# Altium schematic sheet DE-260924.SchDoc(DE-260924)
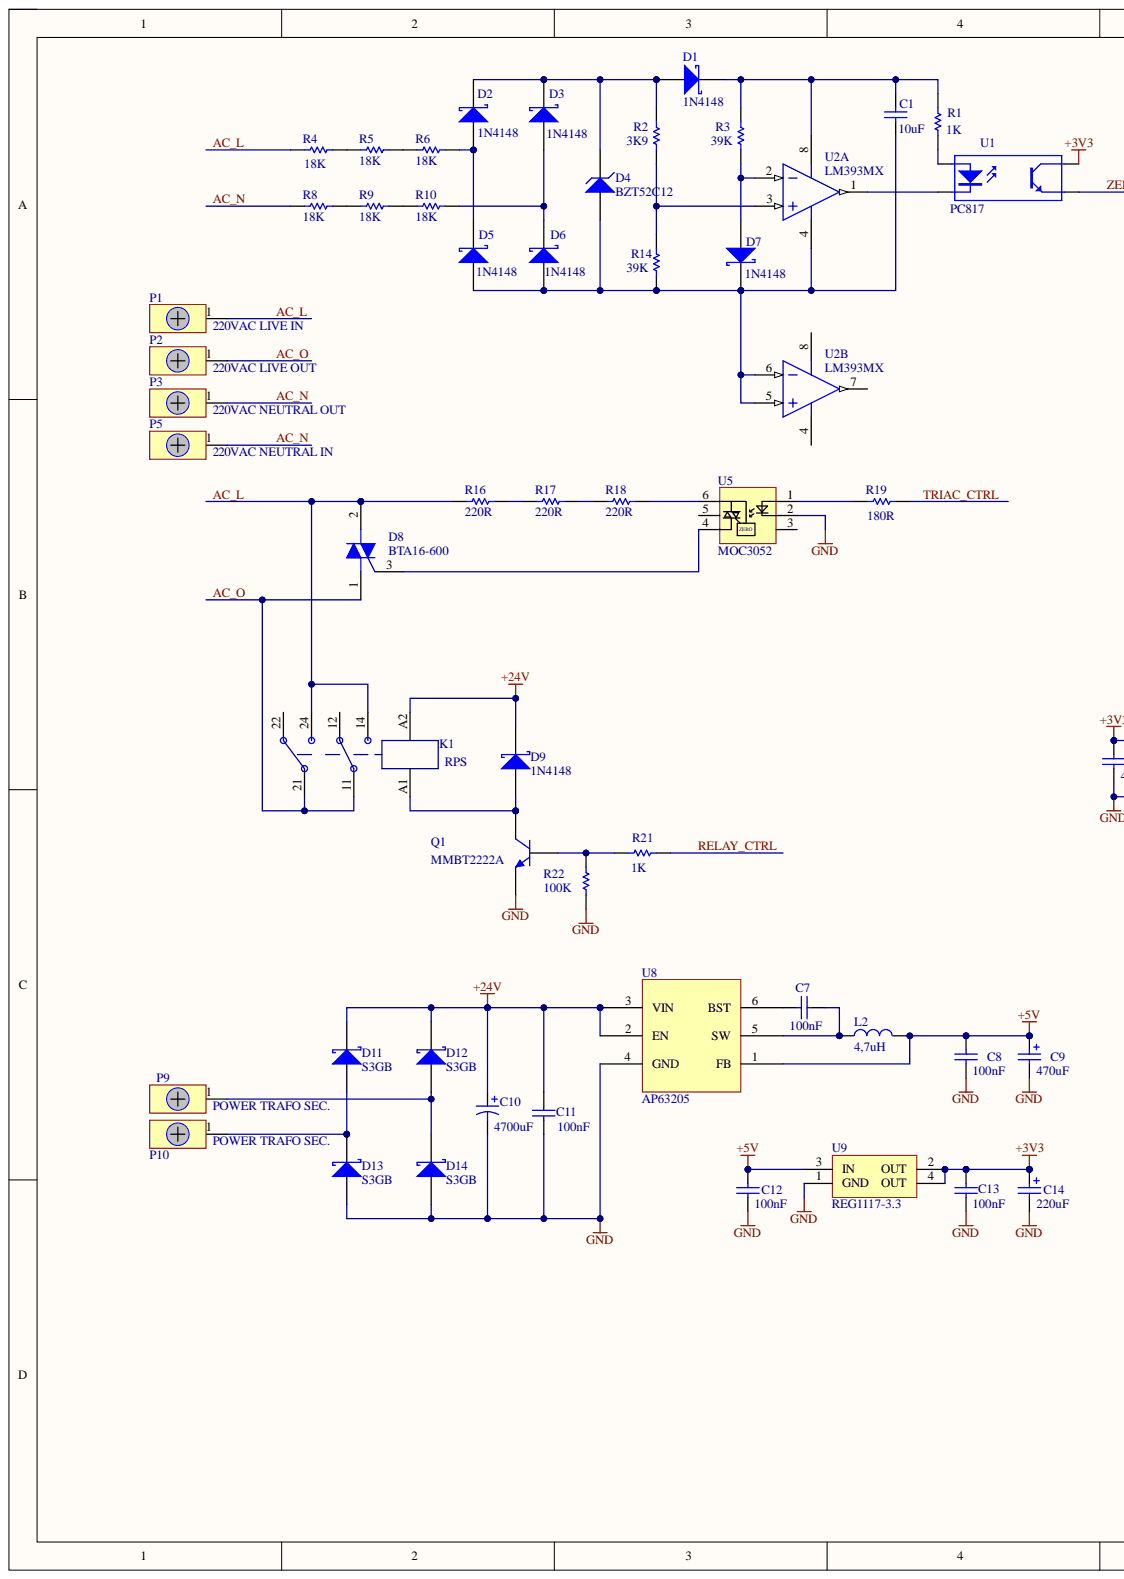
[diagram p0: DE-260924.SchDoc, left]
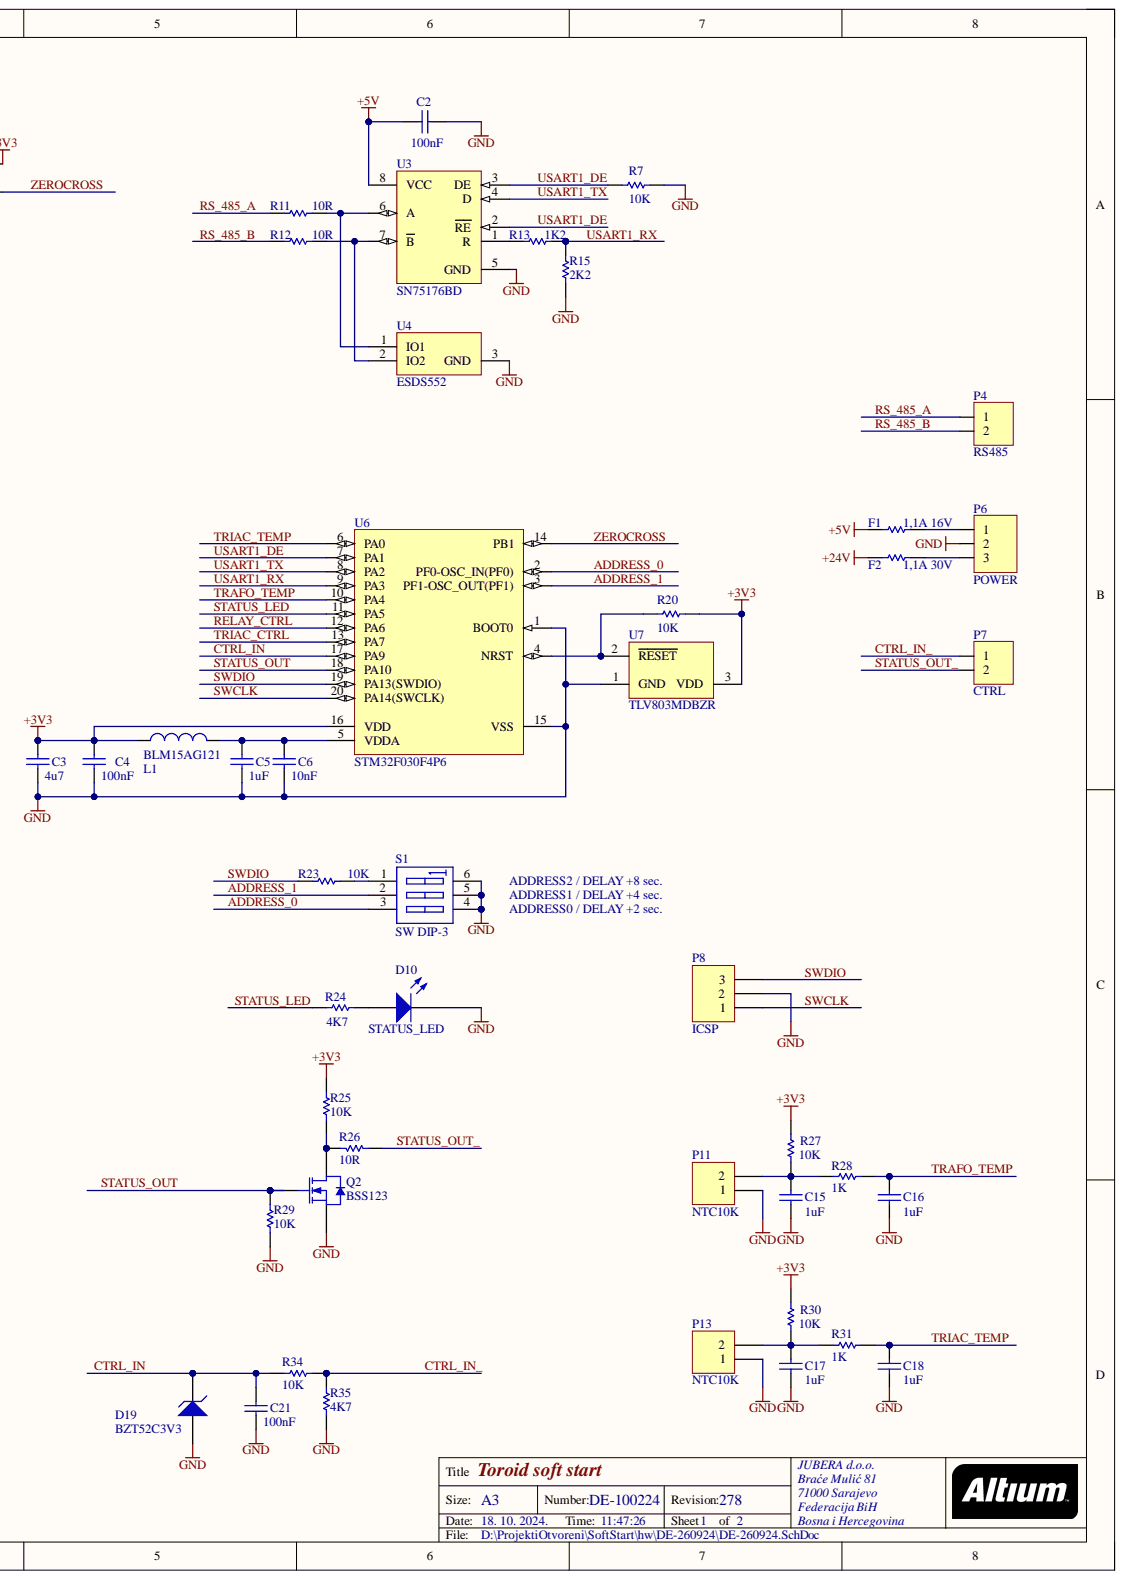
[diagram p1: DE-260924.SchDoc, right]

## Parts
C1  value 10uF  [0805]
C2  value 100nF  [0603]
C3  value 4u7  [0805]  (hidden comment: C0805)
C4  value 100nF  [0603]  (hidden comment: C0603)
C5  value 1uF  [0603]  (hidden comment: C0603)
C6  value 10nF  [0603]  (hidden comment: C0603)
C7  value 100nF  [0603]
C8  value 100nF  [0603]  (hidden comment: C0603)
C9  470uF  [SMD,D6.3xL7.7mm Aluminum Electrolytic Capacitors]
C10  4700uF  [CAPPR5-13X25]
C11  value 100nF  [0603]
C12  value 100nF  [0603]
C13  value 100nF  [0603]
C14  220uF  [SMD,D6.3xL7.7mm Aluminum Electrolytic Capacitors]
C15  1uF  [0603]
C16  value 1uF  [0603]
C17  value 1uF  [0603]
C18  value 1uF  [0603]
C21  value 100nF  [0603]
D1  1N4148  [SOD323]
D2  1N4148  [SOD323]
D3  1N4148  [SOD323]
D4  BZT52C12  [SOD-123]
D5  1N4148  [SOD323]
D6  1N4148  [SOD323]
D7  1N4148  [SOD323]
D8  BTA16-600  [ONSC-TO-220-3-221A-07]
D9  1N4148  [SOD323]
D10  STATUS_LED  [1.6X0.8X0.8]
D11  S3GB  [SMB]
D12  S3GB  [SMB]
D13  S3GB  [SMB]
D14  S3GB  [SMB]
D19  BZT52C3V3  [SOD-123]
F1  1,1A 16V  [12-1812]
F2  1,1A 30V  [12-1812]
K1  RPS  [RPS 2CO 8A 24V]
L1  BLM15AG121  [0603]
L2  4,7uH  [XRNR4030-4.7uH/M]
P1  220VAC LIVE IN  [WIRE PAD]
P2  220VAC LIVE OUT  [WIRE PAD]
P3  220VAC NEUTRAL OUT  [WIRE PAD]
P4  RS485  [TERMINAL BLOK 2]
P5  220VAC NEUTRAL IN  [WIRE PAD]
P6  POWER  [TERMINAL BLOK 3]
P7  CTRL  [TERMINAL BLOK 2]
P8  ICSP  [HDR1X3]
P9  POWER TRAFO SEC.  [WIRE PAD]
P10  POWER TRAFO SEC.  [WIRE PAD]
P11  NTC10K  [HDR1X2]
P13  NTC10K  [HDR1X2]
Q1  MMBT2222A  [SOT23_N]
Q2  BSS123  [SOT23_N]
R1  1K  [ERA-0603]
R2  3K9  [ERA-0603]
R3  39K  [ERA-0603]
R4  value 18K  [ERA-0805]  (hidden comment: 0805)
R5  18K  [ERA-0805]
R6  18K  [ERA-0805]
R7  10K  [ERA-0603]
R8  18K  [ERA-0805]
R9  18K  [ERA-0805]
R10  18K  [ERA-0805]
R11  10R  [ERA-0805]
R12  10R  [ERA-0805]
R13  1K2  [ERA-0603]
R14  39K  [ERA-0603]
R15  2K2  [ERA-0603]
R16  220R  [ERA-0805]
R17  220R  [ERA-0805]
R18  220R  [ERA-0805]
R19  180R  [ERA-0603]
R20  value 10K  [ERA-0603]  (hidden comment: 180R)
R21  1K  [ERA-0603]
R22  100K  [ERA-0603]
R23  value 10K  [ERA-0603]  (hidden comment: 180R)
R24  value 4K7  [ERA-0603]  (hidden comment: 180R)
R25  value 10K  [ERA-0603]  (hidden comment: 180R)
R26  10R  [ERA-0603]
R27  10K  [ERA-0603]
R28  1K  [ERA-0603]
R29  value 10K  [ERA-0603]  (hidden comment: 180R)
R30  10K  [ERA-0603]
R31  1K  [ERA-0603]
R34  value 10K  [ERA-0603]  (hidden comment: 180R)
R35  value 4K7  [ERA-0603]  (hidden comment: 180R)
S1  SW DIP-3  [DIP-6]
U1  PC817  [DIP-4]
U2  LM393MX  [M08A_N]  (parts A,B)
U3  SN75176BD  [D008_N]
U4  ESDS552  [DCK3_N]
U5  MOC3052  [DIP6]
U6  STM32F030F4P6  [STM-TSSOP20_N]
U7  TLV803MDBZR  [DBZ3_N]
U8  AP63205  [TSOT-26]
U9  REG1117-3.3  [ZZ311]

## Nets
+3V3: C3.2 C4.2 C13.2 C14.1 L1.1 R20.1 R25.1 R27.2 R30.2 U1.4 U6.16(VDD) U7.3 U9.2(OUT) U9.4(OUT)
+5V: C2.2 C8.2 C9.1 C12.2 F1.1 L2.1 U3.8(VCC) U8.1(FB) U9.3(IN)
+24V: C10.1 C11.2 D9.1 D11.2 D12.2 F2.1 K1.A2 U8.2(EN) U8.3(VIN)
GND: C2.1 C3.1 C4.1 C5.1 C6.1 C8.1 C9.2 C10.2 C11.1 C12.1 C13.1 C14.2 C15.2 C16.2 C17.2 C18.2 C21.1 D10.2 D13.1 D14.1 D19.1 P6.2 P8.2 P11.1 P13.1 Q1.2 Q2.2 R7.2 R15.1 R22.1 R29.2 R35.2 S1.4 S1.5 S1.6 U3.5(GND) U4.3 U5.2 U6.1(BOOT0) U6.15(VSS) U7.1 U8.4(GND) U9.1(GND)
AC_L: D8.2 K1.14 K1.24 P1.1 R4.1 R16.1
AC_N: P3.1 P5.1 R8.1
AC_O: D8.1 K1.11 K1.21 P2.1
ADDRESS_0: S1.3 U6.2(PF0-OSC_IN(PF0))
ADDRESS_1: S1.2 U6.3(PF1-OSC_OUT(PF1))
CTRL_IN: C21.2 D19.2 R34.1 U6.17(PA9)
CTRL_IN_: P7.1 R34.2 R35.1
RELAY_CTRL: R21.1 U6.12(PA6)
RS_485_A: P4.1 R11.1
RS_485_B: P4.2 R12.1
STATUS_LED: R24.2 U6.11(PA5)
STATUS_OUT: Q2.1 R29.1 U6.18(PA10)
STATUS_OUT_: P7.2 R26.2
SWCLK: P8.1 U6.20(PA14(SWCLK))
SWDIO: P8.3 R23.2 U6.19(PA13(SWDIO))
TRAFO_TEMP: C16.1 R28.1 U6.10(PA4)
TRIAC_CTRL: R19.1 U6.13(PA7)
TRIAC_TEMP: C18.1 R31.1 U6.6(PA0)
USART1_DE: R7.1 U3.2(RE) U3.3(DE) U6.7(PA1)
USART1_RX: R13.2 R15.2 U6.9(PA3)
USART1_TX: U3.4(D) U6.8(PA2)
ZEROCROSS: U1.3 U6.14(PB1)
NetC1_1: C1.1 D4.1 D5.2 D6.2 D7.1 R14.1 U2.4 U2.5 U2.6
NetC1_2: C1.2 D1.1 R1.2 R3.2 U2.8
NetC5_2: C5.2 C6.2 L1.2 U6.5(VDDA)
NetC7_1: C7.1 L2.2 U8.5(SW)
NetC7_2: C7.2 U8.6(BST)
NetC15_1: C15.1 P11.2 R27.1 R28.2
NetC17_1: C17.1 P13.2 R30.1 R31.2
NetD1_2: D1.2 D2.1 D3.1 D4.2 R2.2
NetD2_2: D2.2 D5.1 R6.2
NetD3_2: D3.2 D6.1 R10.2
NetD7_2: D7.2 R3.1 U2.2
NetD8_3: D8.3 U5.4
NetD9_2: D9.2 K1.A1 Q1.3
NetD10_1: D10.1 R24.1
NetD11_1: D11.1 D13.2 P10.1
NetD12_1: D12.1 D14.2 P9.1
NetF1_2: F1.2 P6.1
NetF2_2: F2.2 P6.3
NetQ1_1: Q1.1 R21.2 R22.2
NetQ2_3: Q2.3 R25.2 R26.1
NetR1_1: R1.1 U1.1
NetR2_1: R2.1 R14.2 U2.3
NetR4_2: R4.2 R5.1
NetR5_2: R5.2 R6.1
NetR8_2: R8.2 R9.1
NetR9_2: R9.2 R10.1
NetR11_2: R11.2 U3.6(A) U4.1
NetR12_2: R12.2 U3.7(B) U4.2
NetR13_1: R13.1 U3.1(R)
NetR16_2: R16.2 R17.1
NetR17_2: R17.2 R18.1
NetR18_2: R18.2 U5.6
NetR19_2: R19.2 U5.1
NetR20_2: R20.2 U6.4(NRST) U7.2
NetR23_1: R23.1 S1.1
NetU1_2: U1.2 U2.1

Not connected: K1 12 22; U2 7; U5 3 5

## Library data of the parts
C1 C3 = C0805: Ceramic Chip Capacitor - Standard; Note: Footprint corresponds to dimensions for bulk cassette packaging. This case size has tightened tolerances.; Code_IPC: 2012[0805]; Code_JEITA: 0805; Component Kind: Standard; ComponentLink1Description: Manufacturer Link; ComponentLink1URL: http://www.kemet.com/; ComponentLink2Description: Datasheet; ComponentLink2URL: http://www.kemet.com/kemet/web/homepage/kechome.nsf/vapubfilesname/F3102TA.pdf/$file/F3102TA.pdf; DatasheetDocument: Mar-2001; LatestRevisionDate: 19-Mar-2004; LatestRevisionNote: Silkscreen size reduced.; Library Name: DE-260924.SchLib; PackageDocument: Mar-2001; PackageReference: 0805; Published: 17-Jul-2002; Signal Integrity: C0805; Simulation: CAP; Publisher: Altium Limited
C2 C4-C6 C11 C13 C15-C18 C21 = C0603: Ceramic Chip Capacitor - Standard; Note: Footprint corresponds to dimensions for bulk cassette packaging. This case size has tightened tolerances.; Code_IPC: 1608 [0603]; Code_JEITA: 0603; Component Kind: Standard; ComponentLink1Description: Manufacturer Link; ComponentLink1URL: http://www.kemet.com/; ComponentLink2Description: Datasheet; ComponentLink2URL: http://www.kemet.com/kemet/web/homepage/kechome.nsf/vapubfilesname/F3102TA.pdf/$file/F3102TA.pdf; DatasheetDocument: Mar-2001; LatestRevisionDate: 19-Mar-2004; LatestRevisionNote: Silkscreen size reduced.; Library Name: DE-260924.SchLib; PackageDocument: Mar-2001; PackageReference: 0603; Published: 17-Jul-2002; Signal Integrity: C0603; Simulation: CAP; Publisher: Altium Limited
  C15: Value: 1uF
C7 C8 C12 = C0603: Ceramic Chip Capacitor - 25V; Note: Footprint corresponds to dimensions for bulk cassette packaging. This case size has tightened tolerances.; Code_IPC: 1608 [0603]; Code_JEITA: 0603; Component Kind: Standard; ComponentLink1Description: Manufacturer Link; ComponentLink1URL: http://www.kemet.com/; ComponentLink2Description: Datasheet; ComponentLink2URL: http://www.kemet.com/kemet/web/homepage/kechome.nsf/vapubfilesname/F3102TA.pdf/$file/F3102TA.pdf; DatasheetDocument: Mar-2001; LatestRevisionDate: 19-Mar-2004; LatestRevisionNote: Silkscreen size reduced.; Library Name: DE-260924.SchLib; PackageDocument: Mar-2001; PackageReference: 0603; Published: 17-Jul-2002; Signal Integrity: C0603; Simulation: CAP; Publisher: Altium Limited
C9 = ROQANG RVT1C471M0607: 470uF 16V 185mA@120Hz ±20% SMD,D6.3xL7.7mm Aluminum Electrolytic Capacitors - SMD ROHS; DrillSize: Nominal, Rounded To The Nearest 0.1mm; Note: Refer to Manufacturer's Data Sheets for other lead formations; Value: 10uF; Component Kind: Standard; ComponentLink1Description: Manufacturer Link; ComponentLink1URL: http://www.vishay.com/; ComponentLink2Description: Datasheet; ComponentLink2URL: http://www.vishay.com/docs/25014/eka.pdf; DatasheetDocument: 20-Feb-2001; Library Name: DE-260924.SchLib; PackageReference: CAPPR2-5X11; Published: 19-Mar-2004; Publisher: Altium Limited
C10 = EKA00GG447C00: Aluminum Electrolytic Capacitor, Radial Style with Long Leads: 4700uF, 10V; DrillSize: Nominal, Rounded To The Nearest 0.1mm; Note: Refer to Manufacturer's Data Sheets for other lead formations; Component Kind: Standard; ComponentLink1Description: Manufacturer Link; ComponentLink1URL: http://www.vishay.com/; ComponentLink2Description: Datasheet; ComponentLink2URL: http://www.vishay.com/docs/25014/eka.pdf; DatasheetDocument: 20-Feb-2001; PackageReference: CAPPR5-13X25; Published: 19-Mar-2004; Signal Integrity: EKA00GG447C00; Simulation: CAP; Value: 4700uF; Library Name: Vishay Roederstein Electrolytic Radial-Lead Capacitor.IntLib; Publisher: Altium Limited
C14 = ROQANG VT1C221M0607: 220uF 16V 110mA@120Hz ±20% SMD,D6.3xL7.7mm Aluminum Electrolytic Capacitors - SMD ROHS; DrillSize: Nominal, Rounded To The Nearest 0.1mm; Note: Refer to Manufacturer's Data Sheets for other lead formations; Value: 10uF; Component Kind: Standard; ComponentLink1Description: Manufacturer Link; ComponentLink1URL: http://www.vishay.com/; ComponentLink2Description: Datasheet; ComponentLink2URL: http://www.vishay.com/docs/25014/eka.pdf; DatasheetDocument: 20-Feb-2001; Library Name: DE-260924.SchLib; PackageReference: CAPPR2-5X11; Published: 19-Mar-2004; Publisher: Altium Limited
D1-D3 D5-D7 D9 = 1PS76SB10: Schottky Barrier Diode; Component Kind: Standard; ComponentLink1Description: Manufacturer Link; ComponentLink1URL: http://www.semiconductors.philips.com/; ComponentLink2Description: Datasheet; ComponentLink2URL: http://www.semiconductors.philips.com/acrobat/datasheets/1PS76SB10_2.pdf; DatasheetVersion: 14-Oct-1996; LatestRevisionDate: 19-Mar-2004; LatestRevisionNote: Silkscreen size reduced.; Library Name: DE-100224.SchLib; PackageDescription: SOD-323/SC-76; 2 Leads; Body 1.7 x 1.3 mm, (LxW); PackageReference: SOD323; PackageVersion: May-1999; Published: 17-Sep-2003; Signal Integrity: 1PS76SB10; Simulation: D1PS76SBD10; Publisher: Altium Limited
D4 = BZT52C12: Surface Mount Zener Diode; Component Kind: Standard; ComponentLink1Description: Manufacturer Link; ComponentLink1URL: http://www.vishay.com/; DatasheetDocument: REV. L-2; LatestRevisionDate: 17-Jul-2002; LatestRevisionNote: Re-released for DXP Platform.; Library Name: Vishay Lite-On Discrete Diode.IntLib; PackageReference: SOD-123; Published: 8-Jun-2000; Signal Integrity: BZT52C12; Simulation: BZT52C12; Publisher: Altium Limited
D8 = BTA08-600BW3G: Triacs, Silicon Bidirectional Thyristor, 3-Pin TO-220, Pb-Free, Tube; Manufacturer: On Semiconductor; IGT Max (mA): 50; IT(RMS) Max (A): 8; ITSM Max (A): 90; Component Kind: Standard; ComponentLink1Description: Manufacturer URL; ComponentLink1URL: http://www.onsemi.com/; ComponentLink2Description: Datasheet; ComponentLink2URL: http://www.onsemi.com/pub_link/Collateral/BTA08-600BW3-D.PDF; ComponentLink3Description: Package Specification; ComponentLink3URL: http://www.onsemi.com/pub_link/Collateral/221A-07.PDF; DatasheetVersion: Rev. 1; Library Name: DE-100224.SchLib; Mounting Technology: Through Hole; PackageDescription: 3-Pin Flange Mount, Vertical Straight Lead, Body 9.97 x 4.445 mm, Pitch 2.54 mm; PackageReference: TO-220-3-221A-07; PackageVersion: Rev. O, 07/2008; Packing: Tube; PartNumber: BTA08-600BW3G; RoHS: Pb-Free; Supplier 1: Digi-Key; Supplier 2: Newark; Supplier 3: Mouser; Supplier Part Number 1: BTA08-600BW3G-ND; Supplier Part Number 2: 13P2072; Supplier Part Number 3: 863-BTA08-600BW3G
D10 = HSMB-C190: Blue Surface Mount Chip LED, Blue; LuminousIntensity: 1.6mcd (Minimum).; PCB_Layout: Complies with manufacturer's recommendation.; Component Kind: Standard; ComponentLink1Description: Manufacturer Link; ComponentLink1URL: http://www.avagotech.com/; ComponentLink2Description: Datasheet; ComponentLink2URL: http://cp.literature.agilent.com/litweb/pdf/5988-5211EN.pdf; DatasheetVersion: 26-Feb-2002; LED_Colour: Blue; Library Name: DE-160724.SchLib; PackageDescription: SM LED; 2 Flat Leads; PackageReference: 1.6X0.8X0.8; PackageVersion: Mar-2002; Published: 11-Dec-2002; Signal Integrity: LED; Simulation: Agl_HSMBC110; Publisher: Altium Limited
D11-D14 = D Schottky: Schottky Diode; Code_JEDEC: DO-214-AA; Component Kind: Standard; LatestRevisionDate: 17-Jul-2002; LatestRevisionNote: Re-released for DXP Platform.; Library Name: Miscellaneous Devices.IntLib; PackageDescription: DO-214-AA/SMB; 2 C-Bend Leads; Body 5.3 x 3.6 mm, inc. leads (LxW); PackageReference: SMB; Published: 8-Jun-2000; Signal Integrity: D Schottky; Simulation: SKYDIODE; Publisher: Altium Limited
D19 = BZT52C3V3: Surface Mount Zener Diode; Component Kind: Standard; ComponentLink1Description: Manufacturer Link; ComponentLink1URL: http://www.vishay.com/; DatasheetDocument: REV. L-2; LatestRevisionDate: 17-Jul-2002; LatestRevisionNote: Re-released for DXP Platform.; Library Name: Vishay Lite-On Discrete Diode.IntLib; PackageReference: SOD-123; Published: 8-Jun-2000; Signal Integrity: BZT52C3V3; Simulation: BZT52C3V3; Publisher: Altium Limited
F1 F2 = ERJL12: Retriggerable SMD fuse 1,1A, 16V; Component Kind: Standard; ComponentLink1Description: Manufacturer Link; ComponentLink1URL: http://www.panasonic.com/industrial/components/resistive_products.htm; ComponentLink2Description: Datasheet; ComponentLink2URL: http://www.panasonic.com/industrial/components/pdf/AOA0000CE3.pdf; LatestRevisionDate: 19-Mar-2004; LatestRevisionNote: Silkscreen size reduced.; Library Name: DE-260924.SchLib; PackageDocument: Mar-2003; PackageReference: 12-1812; Published: 17-Dec-2003; Signal Integrity: Res; Simulation: RESISTOR; Publisher: Altium Limited
K1 = PCB relay 2CO, 8A, 24VDC, RPS serie 5mm: Component Kind: Standard; Library Name: DE-260924.SchLib
L1 = Chip inductor: SMD chip inductor; Code_IEC: 1005; Code_JEITA: 0402; Component Kind: Standard; LatestRevisionDate: 29-May-2009; LatestRevisionNote: IPC-7351 Footprint Added.; Library Name: DE-260924.SchLib; PackageDescription: Chip Inductor; PackageReference: 0402-A; Published: 8-Jun-2000; Signal Integrity: Inductor; Simulation: INDUCTOR; Publisher: Altium Limited
L2 = Inductor: Component Kind: Standard; Library Name: DE-260924.SchLib
P1-P3 P5 P9 P10 = Wire Lug: Component Kind: Standard; Library Name: DE-260924.SchLib
P4 P7 = TERMINAL BLOK 2: Terminal blok konektor 3,5 mm; Component Kind: Standard; Library Name: DE-160724.SchLib
P6 = TERMINAL BLOK 3: 3 pinski terminal blok konektor; Component Kind: Standard; Library Name: DE-100224.SchLib
P8 = Header 3: Header, 3-Pin; Component Kind: Standard; LatestRevisionDate: 17-Jul-2002; LatestRevisionNote: Re-released for DXP Platform.; Library Name: Miscellaneous Connectors.IntLib; Signal Integrity: Connector; Publisher: Altium Limited
P11 P13 = Header 2: Header, 2-Pin; Component Kind: Standard; LatestRevisionDate: 17-Jul-2002; LatestRevisionNote: Re-released for DXP Platform.; Library Name: Miscellaneous Connectors.IntLib; Signal Integrity: Connector; Publisher: Altium Limited
Q1 = BC817: NPN General-purpose Transistor; Component Kind: Standard; ComponentLink1Description: Manufacturer Link; ComponentLink1URL: http://www.semiconductors.philips.com/; ComponentLink2Description: Datasheet; ComponentLink2URL: http://www.semiconductors.philips.com/acrobat/datasheets/BC817_BC817W_BC337_5.pdf; DatasheetVersion: Jun-1999; LatestRevisionDate: 12-Dec-2006; LatestRevisionNote: IPC-7351 Footprint Added.; Library Name: DE-100224.SchLib; PackageDescription: 3-Pin SOT-23 Package 0.95 mm Pitch, 2.3 mm Lead Span; PackageReference: SOT23; PackageVersion: May-1999; Published: 17-Sep-2003; Signal Integrity: BC817; Simulation: QBC817; Publisher: Altium Limited
Q2 = BSS123: N-channel Enhancement Mode Vertical D-MOSFET; Component Kind: Standard; ComponentLink1Description: Manufacturer Link; ComponentLink1URL: http://www.semiconductors.philips.com/; ComponentLink2Description: Datasheet; ComponentLink2URL: http://www.semiconductors.philips.com/acrobat/datasheets/BSS123_3.pdf; DatasheetVersion: Apr-1995; LatestRevisionDate: 12-Dec-2006; LatestRevisionNote: IPC-7351 Footprint Added.; Library Name: DE-260924.SchLib; PackageDescription: 3-Pin SOT-23 Package 0.95 mm Pitch, 2.3 mm Lead Span; PackageReference: SOT23; PackageVersion: May-1999; Published: 17-Sep-2003; Simulation: BSS123; Publisher: Altium Limited
R1-R3 R7 R13-R15 R19-R25 R27-R31 R34 R35 = ERAV: Metal Film Thermosensitive Chip Resistor, 0.56 Ohm to 10k Ohm Range, 5% Tolerance, 0603 Size, 0.063 W; Note: If flow soldering, adjust pad width of PCB Footprint to 0.7 - 0.8 times the width of the resistor.; Code_IPC: 1608 [0603]; Component Kind: Standard; ComponentLink1Description: Manufacturer Link; ComponentLink1URL: http://www.panasonic.com/industrial/components/resistive_products.htm; ComponentLink2Description: Datasheet; ComponentLink2URL: http://www.panasonic.com/industrial/components/pdf/AOF0000CE1.pdf; LatestRevisionDate: 19-Mar-2004; LatestRevisionNote: Silkscreen size reduced.; PackageDocument: Mar-2003; PackageReference: ERA-0603; Published: 17-Dec-2003; Signal Integrity: Res; Simulation: RESISTOR; Publisher: Altium Limited
  R1-R3 R14 R20-R25 R27-R31 R34 R35: Library Name: DE-100224.SchLib
  R7 R13 R15: Library Name: DE-150824.SchLib
  R19: Library Name: DE-100224.SchLib; Value: 180R
R4-R6 R8-R12 R16-R18 = ERAS: Metal Film Thermosensitive Chip Resistor, 0.56 Ohm to 10k Ohm Range, 5% Tolerance, 0805 Size, 0.1 W; Note: If flow soldering, adjust pad width of PCB Footprint to 0.7 - 0.8 times the width of the resistor.; Code_IPC: 2012 [0805]; Component Kind: Standard; ComponentLink1Description: Manufacturer Link; ComponentLink1URL: http://www.panasonic.com/industrial/components/resistive_products.htm; ComponentLink2Description: Datasheet; ComponentLink2URL: http://www.panasonic.com/industrial/components/pdf/AOF0000CE1.pdf; LatestRevisionDate: 19-Mar-2004; LatestRevisionNote: Silkscreen size reduced.; PackageDocument: Mar-2003; PackageReference: ERA-0805; Published: 17-Dec-2003; Signal Integrity: Res; Simulation: RESISTOR; Publisher: Altium Limited
  R4-R6 R8-R10 R16-R18: Library Name: DE-100224.SchLib
  R11 R12: Library Name: DE-150824.SchLib
R26 = ERA6Y: Rectangular Thick Film Chip Resistor, 10 Ohm to 1M Ohm Range, 0.1% and 0.5% Tolerance, 0805 Size, 0.1 W; Note: If flow soldering, adjust pad width of PCB Footprint to 0.7 - 0.8 times the width of the resistor.; Code_IPC: 2012 [0805]; Component Kind: Standard; ComponentLink1Description: Manufacturer Link; ComponentLink1URL: http://www.panasonic.com/industrial/components/resistive_products.htm; ComponentLink2Description: Datasheet; ComponentLink2URL: http://www.panasonic.com/industrial/components/pdf/AOA0000CE8.pdf; LatestRevisionDate: 19-Mar-2004; LatestRevisionNote: Silkscreen size reduced.; Library Name: DE-280817.SchLib; PackageDocument: Mar-2003; PackageReference: ERA-0805; Published: 17-Dec-2003; Signal Integrity: Res; Simulation: RESISTOR; Publisher: Altium Limited
S1 = SW DIP-3: DIP Switch, 3 Position, SPST; Code_JEDEC: MO-001-AM; Component Kind: Standard; LatestRevisionDate: 17-Jul-2002; LatestRevisionNote: Re-released for DXP Platform.; Library Name: Miscellaneous Devices.IntLib; PackageReference: DIP-6; Published: 24-Mar-1999; Simulation: dpsw3; Publisher: Altium Limited
U1 = PC817: 4-Pin Phototransistor Optocoupler; Component Kind: Standard; DatasheetVersion: Nov-2003; LatestRevisionDate: 04-Mar-2005; LatestRevisionNote: Sch pins 3 & 4 Swapped.; Library Name: DE-100224.SchLib; PackageReference: DIP-4; Published: 24-Mar-1999; Publisher: Altium Limited
U2 = LM393MX: Low Power Low Offset Voltage Dual Comparator, 8-pin Narrow SOIC; Manufacturer: National Semiconductor; High-Reliability: Y; PackageTopMarking: NSZXTT*LM*393M; PowerWise: N; Code_IPC: SOIC127P600-8; Code_JEDEC: MS-012-AA; Component Kind: Standard; ComponentLink1Description: Manufacturer URL; ComponentLink1URL: http://www.national.com/; ComponentLink2Description: LM393 Datasheet; ComponentLink2URL: http://www.national.com/ds/LM/LM393.pdf; ComponentLink3Description: LM393 Web Page; ComponentLink3URL: http://www.national.com/pf/LM/LM393.html; ComponentLink4Description: M08A Package Page; ComponentLink4URL: http://www.national.com/packaging/folders/m08a.html; CompType: Comparator; DatasheetVersion: Aug-2002; Library Name: National Semiconductor Comparator.IntLib; PackageDescription: SOIC Narrow, 4.9 x 3.9 x 1.45mm, 8 Lead, 1.27mm Pitch; PackageReference: M08A; PackageVersion: revM, May-2009; PartNumber: LM393MX; PinCount: 8; RoHS: N
U3 = SN75176BD: Differential Bus Transceiver; Note: Receiver Hysteresis of 50mV Typical; Code_IPC: SOIC127P600-8; Component Kind: Standard; ComponentLink1Description: Manufacturer Link; ComponentLink1URL: http://www.ti.com/; ComponentLink2Description: Datasheet; ComponentLink2URL: http://www-s.ti.com/sc/ds/sn75176b.pdf; DatasheetVersion: Sep-1989; LatestRevisionDate: 13-Apr-2006; LatestRevisionNote: IPC-7351 Footprint Added.; Library Name: DE-150824.SchLib; PackageDescription: 8-Pin Small Outline Integrated Circuit 1.27 mm Pitch; PackageReference: D008; PackageVersion: Jan-1998; PCB3D: D008; Published: 15-May-2002; Publisher: Altium Limited
U4 = TPD2E007DCKR: ESD Protection Array for AC Signal Data Interface, 2 Channels, -40 to +85 degC, 3-pin SC70 (DCK), Green (RoHS & no Sb/Br); Manufacturer: Texas Instruments; Breakdown Voltage(Min)(V): +/-14; IEC 61000-4-2 Air-Gap(+/- kV): 15; IEC 61000-4-2 Contact(+/- kV): 8; IO Leakage Current(nA): 50; SubFamily: ESD Solution; Component Kind: Standard; ComponentLink1Description: Datasheet; ComponentLink1URL: http://www.ti.com/general/docs/lit/getliterature.tsp?genericPartNumber=TPD2E007&fileType=pdf; ComponentLink2Description: URL Link1; ComponentLink2URL: SamplesURL:http://www.ti.com/product/tpd2e007#samples; DatasheetVersion: SLVS796E; Eco-Plan: Green (RoHS & no Sb/Br); IO Capacitance(Typ)(pF): 15; Library Name: TI Interface ESD EMI Protection.IntLib; Mounting Technology: Surface Mount; PackageDescription: 3-Pin Plastic Small-Outline Package, 0.65 mm Pitch, 2.1 mm Lead Span; PackageReference: DCK3; PackageVersion: revG, Jan-2007; PartNumber: TPD2E007DCKR; Supplier 1: Digi-Key; Supplier 2: Digi-Key; Supplier 3: Digi-Key; Supplier 4: Farnell; Supplier 5: Newark; Supplier 6: Newark; Supplier 7: Mouser; Supplier Part Number 1: 296-25281-2-ND; Supplier Part Number 2: 296-25281-1-ND; Supplier Part Number 3: 296-25281-6-ND; Supplier Part Number 4: 2120465; Supplier Part Number 5: 94T5089; Supplier Part Number 6: 55R7554; Supplier Part Number 7: 595-TPD2E007DCKR
U5 = MOC3081: Zero-Cross Optoisolator Triac Driver; Component Kind: Standard; Library Name: DE-100224.SchLib
U6 = STM32F030F4P6: ARM Cortex-M0 32-bit MCU, 16 KB Flash, 4 KB Internal RAM, 15 I/Os, 20-pin TSSOP, -40 to 85 degC, Tube; FLASH Size (Prog) (kB): 16; I/Os (High Current): 15; Internal RAM Size (kB): 4; Operating Frequency(F) (Processor speed) (MHz): 48; Supply Voltage(Vcc) min (V): 2.4; Component Kind: Standard; ComponentLink1Description: Manufacturer URL; ComponentLink1URL: http://www.st.com/web/en/home.html; ComponentLink2Description: Datasheet; ComponentLink2URL: http://www.st.com/st-web-ui/static/active/en/resource/technical/document/datasheet/DM00088500.pdf; ComponentLink3Description: Package Specification; ComponentLink3URL: http://www.st.com/st-web-ui/static/active/en/resource/technical/document/datasheet/DM00065136.pdf; Core: ARM Cortex-M0; DatasheetVersion: Rev. 1; Library Name: STMicroelectronics STM32 F0.IntLib; Mounting Technology: Surface Mount; PackageDescription: 20-Pin Thin Shrink Small Outline Package, 6.5 x 4.4 mm Body, 0.65 mm Pitch; PackageReference: TSSOP20; PackageVersion: Rev. 1, 11/2012; Packing: Tube; PartNumber: STM32F030F4P6; Supplier 1: Digi-Key; Supplier 2: Newark; Supplier 3: Mouser; Supplier Part Number 1: 497-14044-5-ND; Supplier Part Number 2: 06X3648; Supplier Part Number 3: 511-STM32F030F4P6
U7 = TLV803MDBZR: Voltage Supervisor with Active-Low, Open Drain Reset, 1 Supply Monitored, -40 to 125 degC, 3-pin SOT-23 (DBZ), Green (RoHS & no Sb/Br); Manufacturer: Texas Instruments; # of supplies monitored: 1; Iq(Typ)(uA): 9; Output Driver Type / Reset Output: Active-low, Open-drain; Rating: Catalog; Reset Threshold Accuracy(%): 2; SubFamily: Supervisor and Reset IC; Threshold Voltage 1 (typ)(Typ)(V): 4.38; Time Delay(ms): 200; VCC(Max)(V): 6; VCC(Min)(V): 1.1; Code_JEDEC: TO-236-AB; Component Kind: Standard; ComponentLink1Description: Datasheet; ComponentLink1URL: http://www.ti.com/general/docs/lit/getliterature.tsp?genericPartNumber=TLV803M&fileType=pdf; DatasheetVersion: SBVS157B; Library Name: TI Power Management Supervisor and Reset IC.IntLib; Mounting Technology: Surface Mount; PackageDescription: 3-Pin Plastic Small-Outline Package, 0.95 mm Pitch, 2.4 mm Lead Span; PackageReference: DBZ3; PackageVersion: revB, Apr-2005; PartNumber: TLV803MDBZR; Supplier 1: Digi-Key; Supplier 2: Digi-Key; Supplier 3: Digi-Key; Supplier 4: Newark; Supplier 5: Mouser; Supplier Part Number 1: 296-29194-2-ND; Supplier Part Number 2: 296-29194-1-ND; Supplier Part Number 3: 296-29194-6-ND; Supplier Part Number 4: 58T0286; Supplier Part Number 5: 595-TLV803MDBZR
U8 = AP63200: 2A synchronous  buck converter; Component Kind: Standard; Library Name: DE-250624.SchLib
U9 = REG1117-3.3: 800mA and 1A Low Dropout Positive Regulator 2.85V, 3.3V, 5V, and Adjustable; Component Kind: Standard; ComponentLink1Description: Manufacturer Link; ComponentLink1URL: http://www.ti.com/; ComponentLink2Description: Datasheet; ComponentLink2URL: http://www-s.ti.com/sc/ds/REG1117.pdf; DatasheetVersion: Sep-1997; LatestRevisionDate: 17-Jul-2002; LatestRevisionNote: Re-released for DXP Platform.; Library Name: DE-251215.SchLib; PackageDescription: SOT-223/SC-73; 4 Leads; Body 6.7 x 7.3 mm, inc. leads (LxW max); PackageReference: ZZ311; PackageVersion: revC; Published: 8-Jun-2000; Publisher: Altium Limited
